# Altium schematic sheet ETHERNET.SchDoc(ETHERNET)
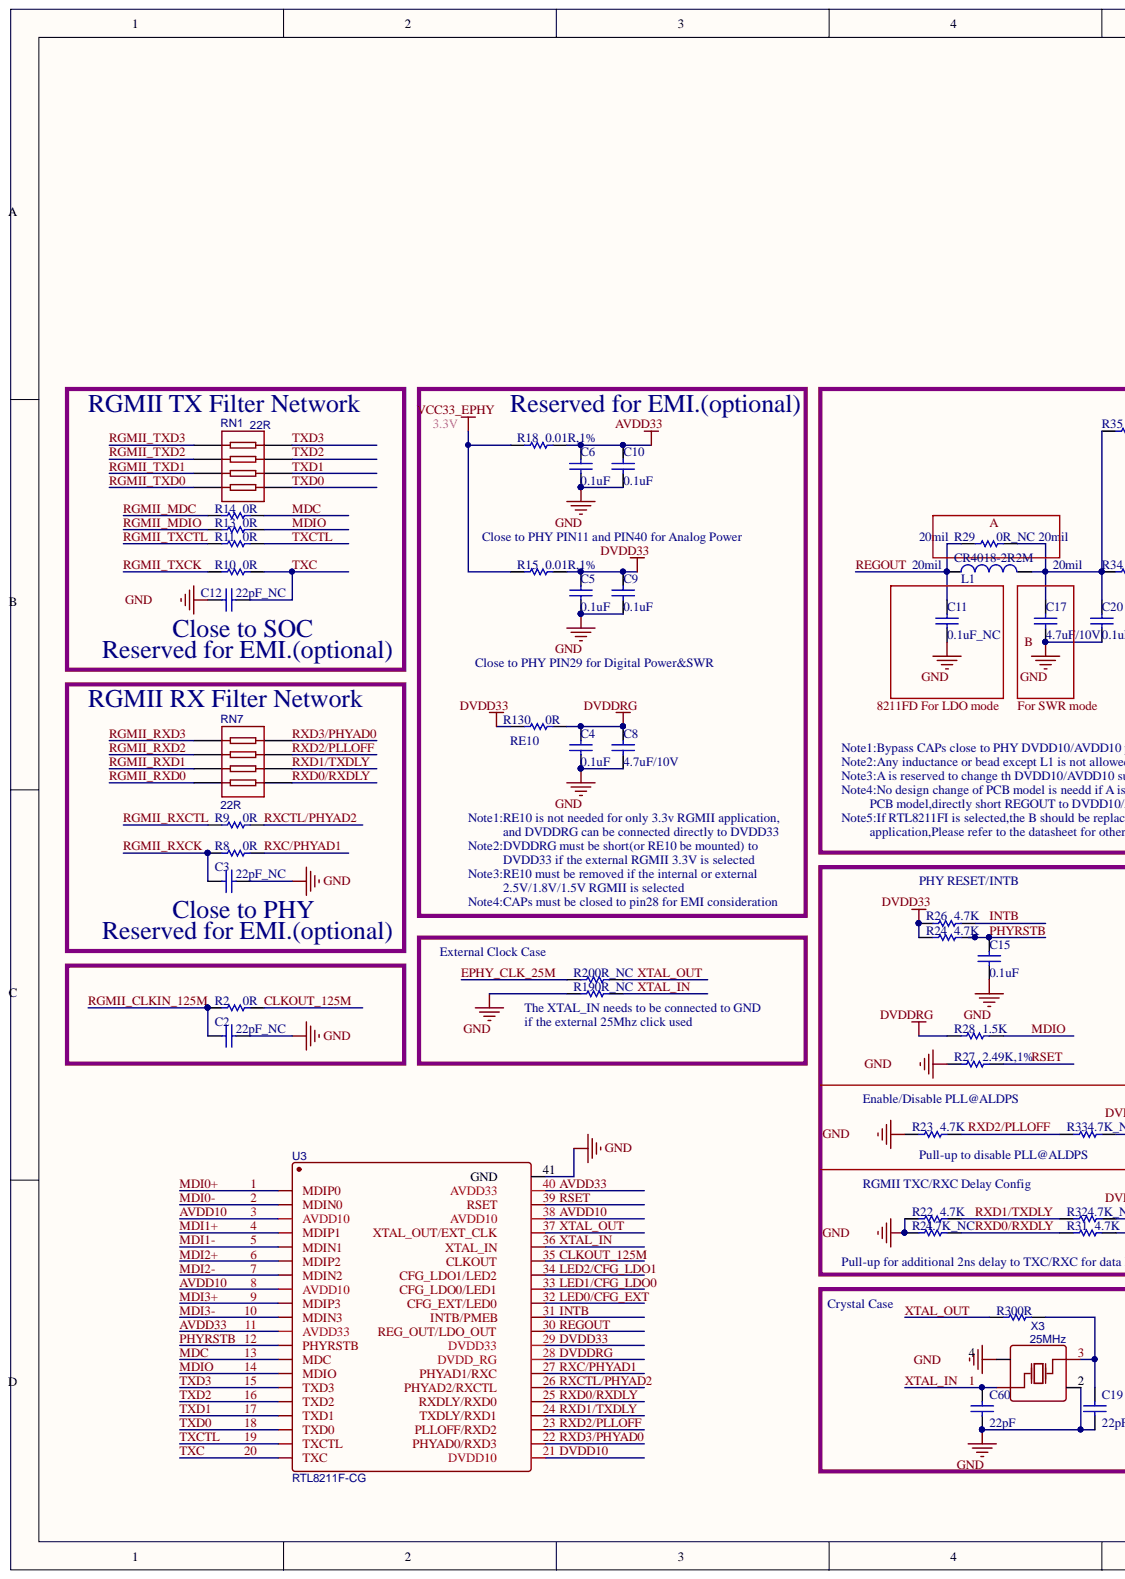
[diagram p0: ETHERNET.SchDoc, left]
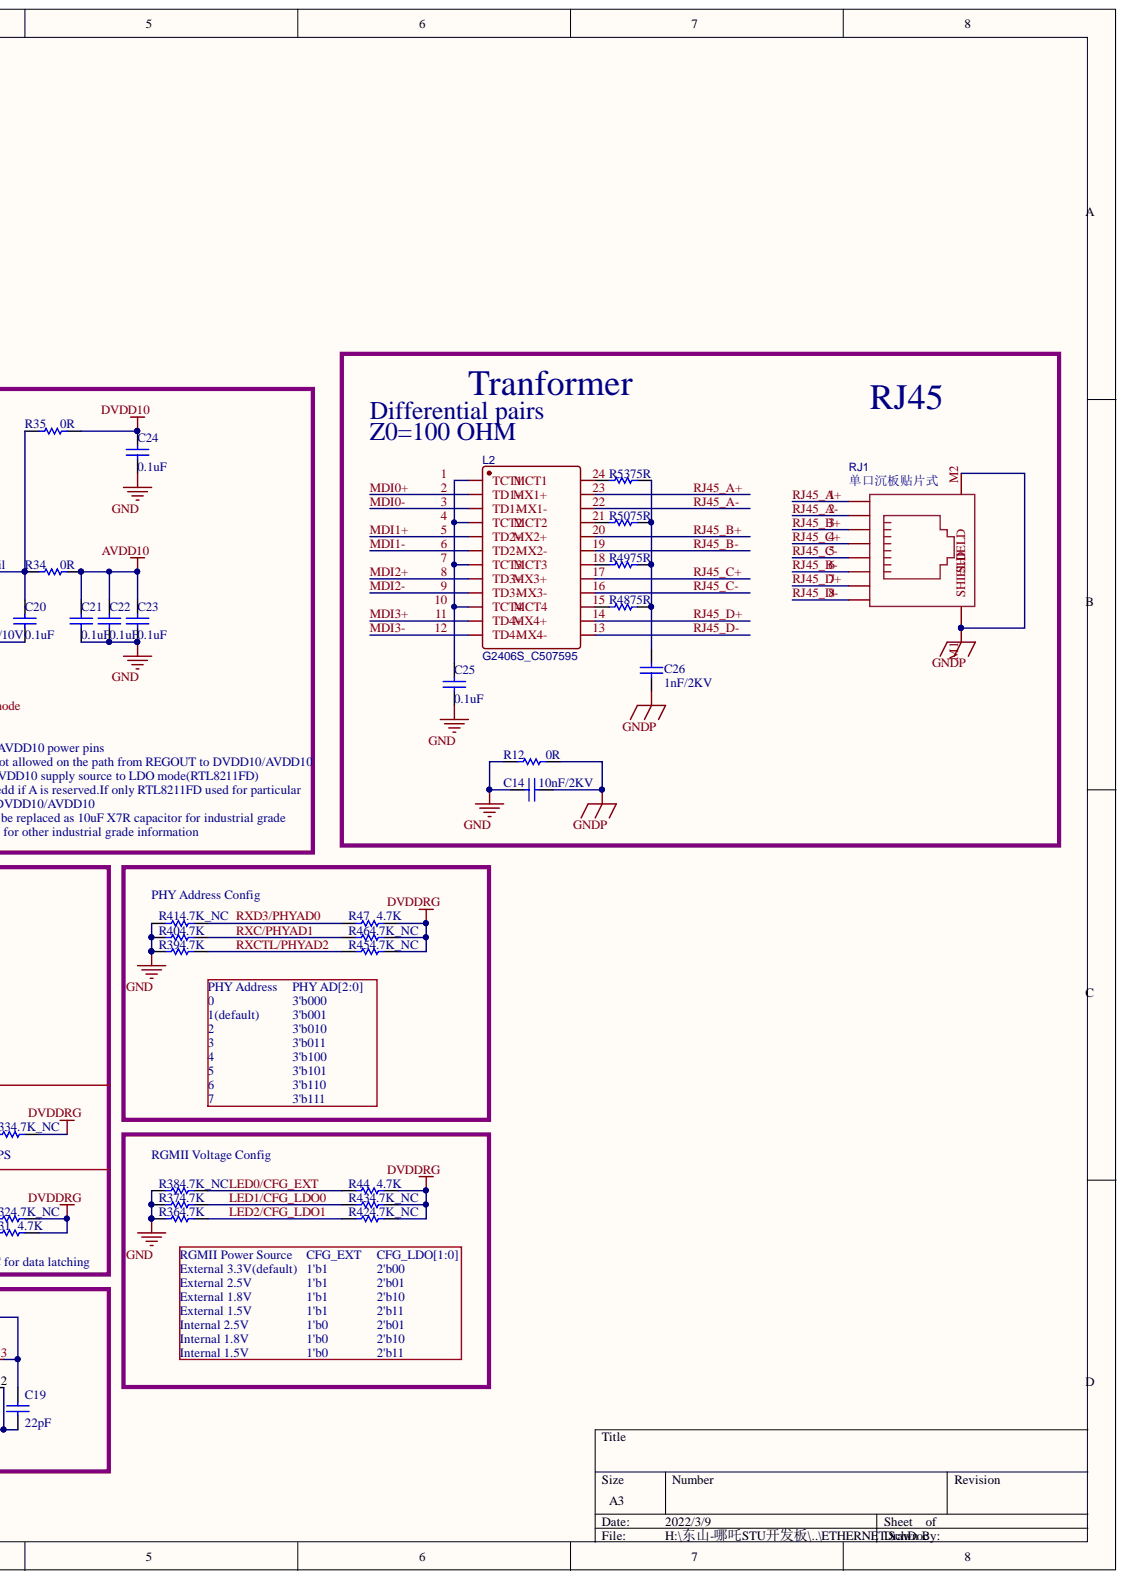
[diagram p1: ETHERNET.SchDoc, right]

## Parts
C2  22pF_NC  [0402]
C3  22pF_NC  [0402]
C4  0.1uF  [0402]
C5  0.1uF  [0402]
C6  0.1uF  [0402]
C8  4.7uF/10V  [0603]
C9  0.1uF  [0402]
C10  0.1uF  [0402]
C11  0.1uF_NC  [0402]
C12  22pF_NC  [0402]
C14  10nF/2KV  [1206C]
C15  0.1uF  [0402]
C17  4.7uF/10V  [0603]
C19  22pF  [0402]
C20  0.1uF  [0402]
C21  0.1uF  [0402]
C22  0.1uF  [0402]
C23  0.1uF  [0402]
C24  0.1uF  [0402]
C25  0.1uF  [0402]
C26  1nF/2KV  [1206C]
C60  22pF  [0402]
L1  CR4018-2R2M  [IND-SMD_L4.0-W4.0]
L2  G2406S_C507595  [SMD-24_L15.1-W7.1-P1.00-LS10.0-BL]
R2  0R  [0402]
R8  0R  [0402]
R9  0R  [0402]
R10  0R  [0402]
R11  0R  [0402]
R12  0R  [1206R]
R13  0R  [0402]
R14  0R  [0402]
R15  0.01R,1%  [0603]
R18  0.01R,1%  [0603]
R19  0R_NC  [0402]
R20  0R_NC  [0402]
R21  4.7K_NC  [0402]
R22  4.7K  [0402]
R23  4.7K  [0402]
R24  4.7K  [0402]
R26  4.7K  [0402]
R27  2.49K,1%  [0402]
R28  1.5K  [0402]
R29  0R_NC  [0603]
R30  0R  [0402]
R31  4.7K  [0402]
R32  4.7K_NC  [0402]
R33  4.7K_NC  [0402]
R34  0R  [0603]
R35  0R  [0603]
R36  4.7K  [0402]
R37  4.7K  [0402]
R38  4.7K_NC  [0402]
R39  4.7K  [0402]
R40  4.7K  [0402]
R41  4.7K_NC  [0402]
R42  4.7K_NC  [0402]
R43  4.7K_NC  [0402]
R44  4.7K  [0402]
R45  4.7K_NC  [0402]
R46  4.7K_NC  [0402]
R47  4.7K  [0402]
R48  75R  [0402]
R49  75R  [0402]
R50  75R  [0402]
R53  75R  [0402]
R130  0R  [0402]
RJ1  单口沉板贴片式  [RJ45_沉板]
RN1  22R  [RES-ARRAY-SMD_0402-8P-L2.0-W1.0-BL]
RN7  22R  [RES-ARRAY-SMD_0402-8P-L2.0-W1.0-BL]
U3  RTL8211F-CG  [WQFN-40_L5.0-W5.0-P0.4-BL-EP]
X3  25MHz  [OSC-SMD_4P-L3.2-W2.5-BL]

## Nets
GND: C2.2 C3.2 C4.2 C5.2 C6.2 C8.2 C9.2 C10.2 C11.2 C12.1 C14.2 C15.2 C17.2 C19.2 C20.2 C21.2 C22.2 C23.2 C24.2 C25.2 C60.2 R12.1 R19.2 R21.2 R22.2 R23.2 R27.2 R36.2 R37.2 R38.2 R39.2 R40.2 R41.2 U3.41 X3.2 X3.4
GNDP: C14.1 C26.1 R12.2 RJ1.M1(SHIELD) RJ1.M2
VCC33_EPHY: R15.2 R18.2
AVDD10: C21.1 C22.1 C23.1 R34.1 U3.3(AVDD10) U3.8(AVDD10) U3.38
AVDD33: C6.1 C10.1 R18.1 U3.11(AVDD33) U3.40
CLKOUT_125M: R2.1 U3.35
DVDD10: C24.1 R35.1 U3.21
DVDD33: C5.1 C9.1 R15.1 R24.2 R26.2 R130.2 U3.29
DVDDRG: C4.1 C8.1 R28.2 R31.1 R32.1 R33.1 R42.1 R43.1 R44.1 R45.1 R46.1 R47.1 R130.1 U3.28
EPHY_CLK_25M: R20.2
INTB: R26.1 U3.31
LED0/CFG_EXT: R38.1 R44.2 U3.32
LED1/CFG_LDO0: R37.1 R43.2 U3.33
LED2/CFG_LDO1: R36.1 R42.2 U3.34
MDC: R14.1 U3.13(MDC)
MDI0+: L2.2(TD1+) U3.1(MDIP0)
MDI0-: L2.3(TD1-) U3.2(MDIN0)
MDI1+: L2.5(TD2+) U3.4(MDIP1)
MDI1-: L2.6(TD2-) U3.5(MDIN1)
MDI2+: L2.8(TD3+) U3.6(MDIP2)
MDI2-: L2.9(TD3-) U3.7(MDIN2)
MDI3+: L2.11(TD4+) U3.9(MDIP3)
MDI3-: L2.12(TD4-) U3.10(MDIN3)
MDIO: R13.1 R28.1 U3.14(MDIO)
PHYRSTB: C15.1 R24.1 U3.12(PHYRSTB)
REGOUT: C11.1 L1.1 R29.2 U3.30
RGMII_CLKIN_125M: C2.1 R2.2
RGMII_MDC: R14.2
RGMII_MDIO: R13.2
RGMII_RXCK: C3.1 R8.2
RGMII_RXCTL: R9.2
RGMII_RXD0: RN7.5
RGMII_RXD1: RN7.6
RGMII_RXD2: RN7.7
RGMII_RXD3: RN7.8
RGMII_TXCK: R10.2
RGMII_TXCTL: R11.2
RGMII_TXD0: RN1.5
RGMII_TXD1: RN1.6
RGMII_TXD2: RN1.7
RGMII_TXD3: RN1.8
RJ45_A+: L2.23 RJ1.1
RJ45_A-: L2.22 RJ1.2
RJ45_B+: L2.20 RJ1.3
RJ45_B-: L2.19 RJ1.6
RJ45_C+: L2.17 RJ1.4
RJ45_C-: L2.16 RJ1.5
RJ45_D+: L2.14 RJ1.7
RJ45_D-: L2.13 RJ1.8
RSET: R27.1 U3.39
RXC/PHYAD1: R8.1 R40.1 R46.2 U3.27
RXCTL/PHYAD2: R9.1 R39.1 R45.2 U3.26
RXD0/RXDLY: R21.1 R31.2 RN7.4 U3.25
RXD1/TXDLY: R22.1 R32.2 RN7.3 U3.24
RXD2/PLLOFF: R23.1 R33.2 RN7.2 U3.23
RXD3/PHYAD0: R41.1 R47.2 RN7.1 U3.22
TXC: C12.2 R10.1 U3.20(TXC)
TXCTL: R11.1 U3.19(TXCTL)
TXD0: RN1.4 U3.18(TXD0)
TXD1: RN1.3 U3.17(TXD1)
TXD2: RN1.2 U3.16(TXD2)
TXD3: RN1.1 U3.15(TXD3)
XTAL_IN: C60.1 R19.1 U3.36 X3.1
XTAL_OUT: R20.1 R30.2 U3.37
NetC17_1: C17.1 C20.1 L1.2 R29.1 R34.2 R35.2
NetC19_1: C19.1 R30.1 X3.3
NetC25_1: C25.1 L2.1(TCT1) L2.4(TCT2) L2.7(TCT3) L2.10(TCT4)
NetC26_2: C26.2 R48.1 R49.1 R50.1 R53.1
NetL2_15: L2.15 R48.2
NetL2_18: L2.18 R49.2
NetL2_21: L2.21 R50.2
NetL2_24: L2.24 R53.2

## Library data of the parts
C2-C6 C8-C12 C14 C15 C17 C20-C26 = Cap: Capacitor; PartNumber: CAP100NF; Component Kind: Standard; LatestRevisionDate: 17-Jul-2002; LatestRevisionNote: Re-released for DXP Platform.; Library Name: Miscellaneous Devices.IntLib; PackageReference: 0402; Published: 8-Jun-2000; Signal Integrity: Cap; Simulation: CAP; Publisher: Altium Limited
  C2 C3 C12: Value: 22pF_NC
  C4-C6 C9 C10 C15 C20-C25: Value: 0.1uF
  C8 C17: Value: 4.7uF/10V
  C11: Value: 0.1uF_NC
  C14: Value: 10nF/2KV
  C26: Value: 1nF/2KV
C19 C60 = Cap: Capacitor; PartNumber: CAP18PF; Component Kind: Standard; LatestRevisionDate: 17-Jul-2002; LatestRevisionNote: Re-released for DXP Platform.; Library Name: Miscellaneous Devices.IntLib; PackageReference: 0402; Published: 8-Jun-2000; Signal Integrity: Cap; Simulation: CAP; Value: 22pF; Publisher: Altium Limited
L1 = Inductor: PartNumber: IND10UH; Code_IEC: 1005; Code_JEITA: 0402; Component Kind: Standard; Description: Inductor; JLC NO: C520302; LatestRevisionDate: 29-May-2009; LatestRevisionNote: IPC-7351 Footprint Added.; Library Name: Miscellaneous Devices.IntLib; PackageDescription: Chip Inductor; PackageReference: 0402-A; Published: 8-Jun-2000; Signal Integrity: Inductor; Simulation: INDUCTOR; Value: CR4018-2R2M; Publisher: Altium Limited
L2 = G2406S_C507595: BOM_Manufacturer: CND-tek; BOM_Manufacturer Part: G2406S; Contributor: LCSC; BOM_JLCPCB Part Class: Extended Part; BOM_Supplier: LCSC; BOM_Supplier Part: C507595; Component Kind: Standard; Library Name: HM2.SchLib; spicePre: L; spiceSymbolName: G2406S_C507595
R2 R8-R11 R13-R15 R18-R24 R26-R50 R53 R130 = Res1: Resistor; PartNumber: RES2.2K; Component Kind: Standard; LatestRevisionDate: 17-Jul-2002; LatestRevisionNote: Re-released for DXP Platform.; Library Name: Miscellaneous Devices.IntLib; PackageReference: 0402; Published: 8-Jun-2000; Signal Integrity: Res; Simulation: RESISTOR; Publisher: Altium Limited
  R2 R8-R11 R13 R14 R30 R34 R35 R130: Value: 0R
  R15 R18: Value: 0.01R,1%
  R19 R20 R29: Value: 0R_NC
  R21 R32 R33 R38 R41-R43 R45 R46: Value: 4.7K_NC
  R22-R24 R26 R31 R36 R37 R39 R40 R44 R47: Value: 4.7K
  R27: Value: 2.49K,1%
  R28: Value: 1.5K
  R48-R50 R53: Value: 75R
R12 = Res1: Resistor; PartNumber: RES22R; Component Kind: Standard; LatestRevisionDate: 17-Jul-2002; LatestRevisionNote: Re-released for DXP Platform.; Library Name: Miscellaneous Devices.IntLib; PackageReference: 0402; Published: 8-Jun-2000; Signal Integrity: Res; Simulation: RESISTOR; Value: 0R; Publisher: Altium Limited
RJ1 = 1-406541-1: BOM_Manufacturer: TE Connectivity; Contributor: LCSC; BOM_JLCPCB Part Class: Extended Part; BOM_Manufacturer Part: 1-406541-1; BOM_Supplier: LCSC; BOM_Supplier Part: C125067; Component Kind: Standard; spicePre: R; spiceSymbolName: 1-406541-1
RN1 RN7 = RES_ARRAY: BOM_Manufacturer: RALEC; BOM_Manufacturer Part: RTA02-4D220JTH; nameAlias: Resistance (Ohms); BOM_JLCPCB Part Class: Extended Part; BOM_Supplier: LCSC; BOM_Supplier Part: C102632; Component Kind: Standard; Library Name: HM2.SchLib; spicePre: R; spiceSymbolName: RTA02-4D220JTH
U3 = RTL8211F-CG: BOM_Manufacturer: Realtek Semicon; Contributor: LCSC; BOM_JLCPCB Part Class: Extended Part; BOM_Manufacturer Part: RTL8211F-CG; BOM_Supplier: LCSC; BOM_Supplier Part: C187932; Component Kind: Standard; Library Name: HM2.SchLib; spicePre: U; spiceSymbolName: RTL8211F-CG
X3 = 24MHz: BOM_Manufacturer: JGHC; Contributor: LCSC; nameAlias: Frequency; VALUE: X322525MOB4SI; BOM_Supplier: LCSC; Component Kind: Standard; JLC NO: C9006; spicePre: X
